# Altium schematic sheet BT2215.SchDoc(BT2215)
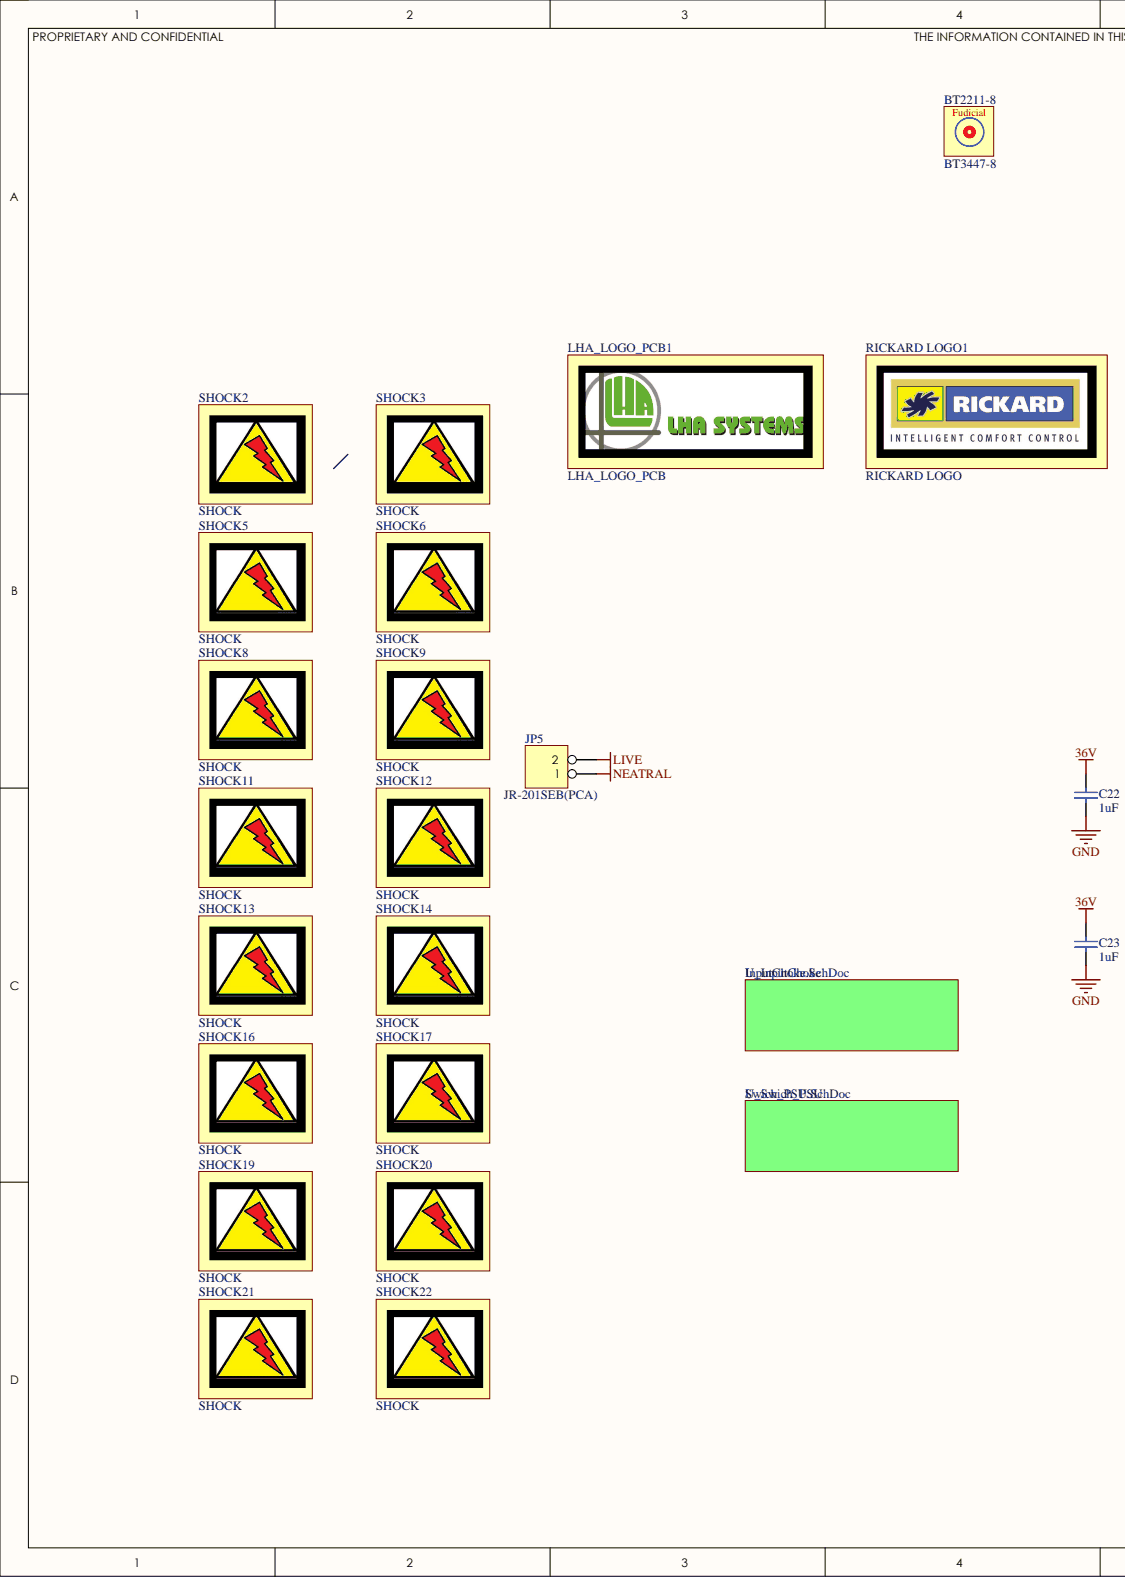
[diagram p0: BT2215.SchDoc, left]
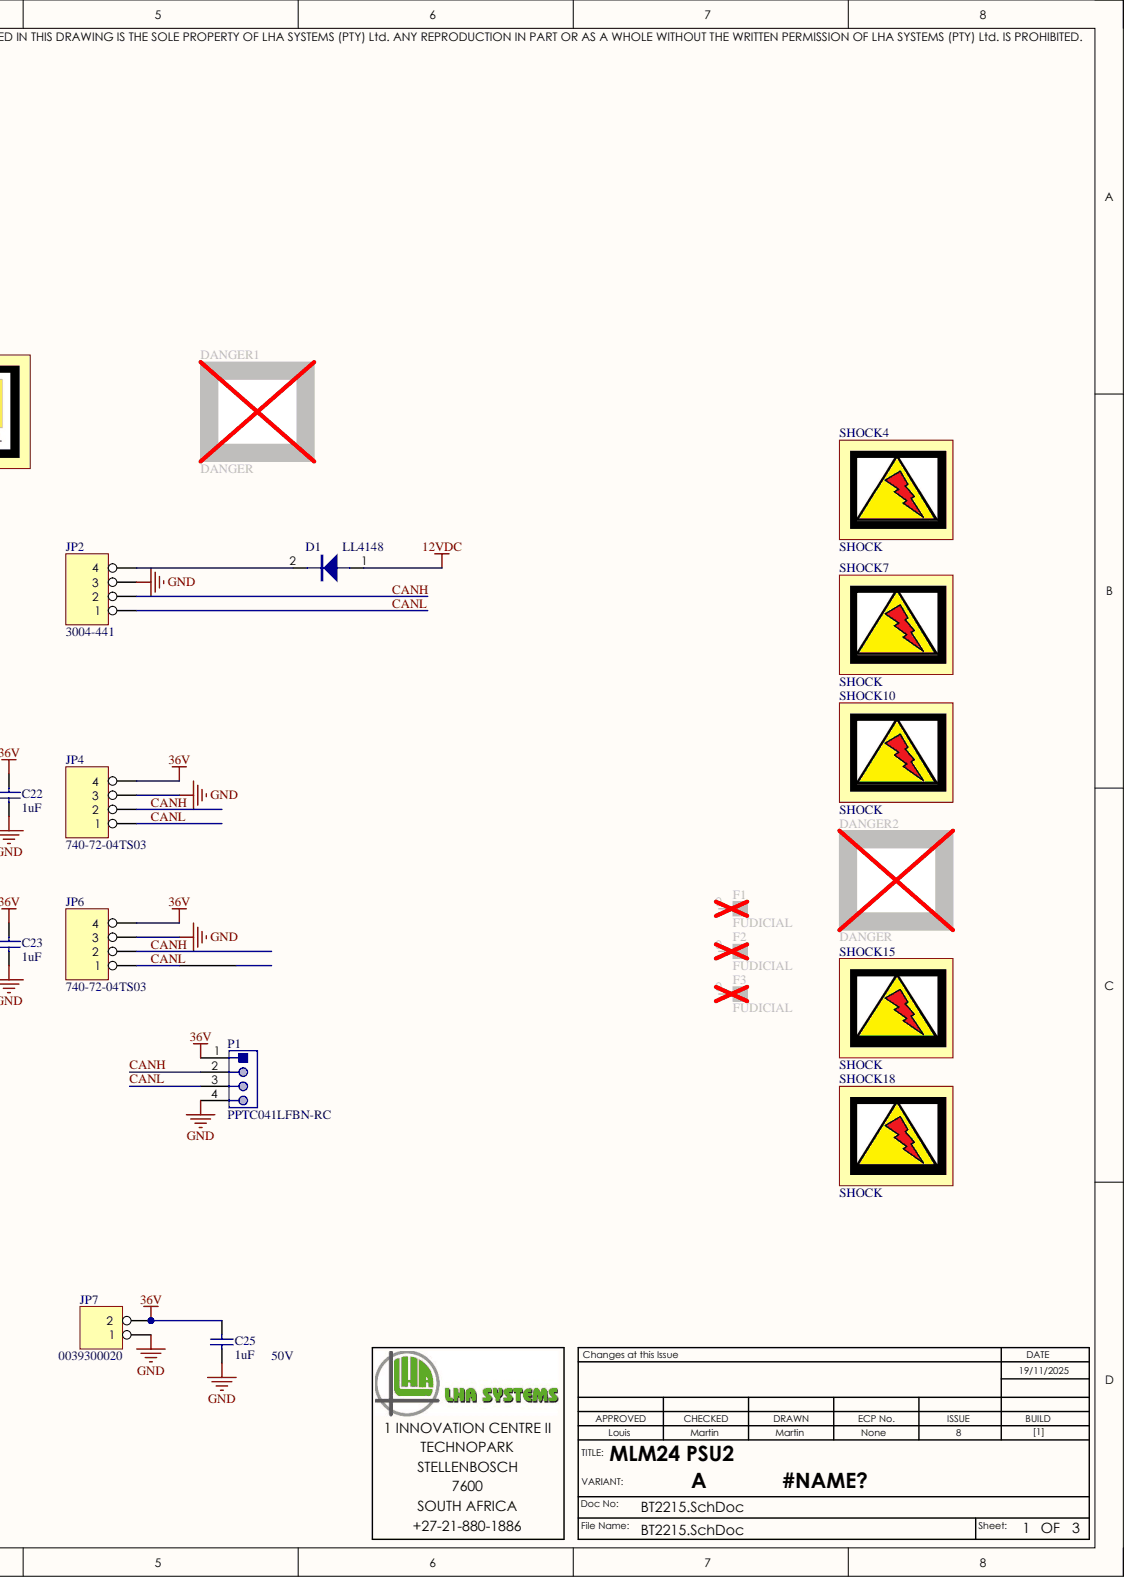
[diagram p1: BT2215.SchDoc, right]

## Parts
BT2211-8  BT3447-8  [PCB_NAME]
C22  1uF  [1206 - OCTO]
C23  1uF  [1206 - OCTO]
C25  1uF  [1206 - OCTO]
D1  LL4148  [MLL34]
DANGER1  DANGER  [DANGER]  (no BOM)
DANGER2  DANGER  [DANGER]  (no BOM)
F1  FUDICIAL  [FUDICIAL]  (no BOM)
F2  FUDICIAL  [FUDICIAL]  (no BOM)
F3  FUDICIAL  [FUDICIAL]  (no BOM)
JP2  3004-441  [RJ9 THL (95003-2441)]
JP4  740-72-04TS03  [MINIFIT-4P]
JP5  JR-201SEB(PCA)  [JR-201SEB(PCA)]
JP6  740-72-04TS03  [MINIFIT-4P]
JP7  0039300020  [MINIFIT JR 2 WAY NO PEG]
LHA_LOGO_PCB1  LHA_LOGO_PCB  [LHA_PCB_LOGO]  (no BOM)
P1  PPTC041LFBN-RC  [Pin Header Female Straight 2.54mm 1x4]
RICKARD_LOGO1  RICKARD LOGO  [RICKARD LOGO]  (no BOM)
SHOCK2  SHOCK  [SHOCK1-SMALL]  (no BOM)
SHOCK3  SHOCK  [SHOCK1-SMALL]  (no BOM)
SHOCK4  SHOCK  [SHOCK1-SMALL]  (no BOM)
SHOCK5  SHOCK  [SHOCK1-SMALL]  (no BOM)
SHOCK6  SHOCK  [SHOCK1-SMALL]  (no BOM)
SHOCK7  SHOCK  [SHOCK1-SMALL]  (no BOM)
SHOCK8  SHOCK  [SHOCK1-SMALL]  (no BOM)
SHOCK9  SHOCK  [SHOCK1-SMALL]  (no BOM)
SHOCK10  SHOCK  [SHOCK1-SMALL]  (no BOM)
SHOCK11  SHOCK  [SHOCK1-SMALL]  (no BOM)
SHOCK12  SHOCK  [SHOCK1-SMALL]  (no BOM)
SHOCK13  SHOCK  [SHOCK1-SMALL]  (no BOM)
SHOCK14  SHOCK  [SHOCK1-SMALL]  (no BOM)
SHOCK15  SHOCK  [SHOCK1-SMALL]  (no BOM)
SHOCK16  SHOCK  [SHOCK1-SMALL]  (no BOM)
SHOCK17  SHOCK  [SHOCK1-SMALL]  (no BOM)
SHOCK18  SHOCK  [SHOCK1-SMALL]  (no BOM)
SHOCK19  SHOCK  [SHOCK1-SMALL]  (no BOM)
SHOCK20  SHOCK  [SHOCK1-SMALL]  (no BOM)
SHOCK21  SHOCK  [SHOCK1-SMALL]  (no BOM)
SHOCK22  SHOCK  [SHOCK1-SMALL]  (no BOM)

## Nets
12VDC: D1.1
36V: C22.2 C23.2 C25.2 JP4.4 JP6.4 JP7.2 P1.1
GND: C22.1 C23.1 C25.1 JP2.3 JP4.3 JP6.3 JP7.1 P1.4
LIVE: JP5.2
NEATRAL: JP5.1
CANH: JP2.2 JP4.2 JP6.2 P1.2
CANL: JP2.1 JP4.1 JP6.1 P1.3
NetD1_2: D1.2 JP2.4

Not connected: F1 0; F2 0; F3 0

## Library data of the parts
BT2211-8 = PCB_NAME_SYSTEM_PARAMETERS: See LHADescription; LHADescription: Printed Circuit Board; Category: PCB; Component Kind: Standard; Library Name: LHA_LIB_Generic.SchLib; Part Description: Printed Circuit Board
C22 C23 C25 = CAP: Cap Ceramic 10%; Manufacturer: KEMET; Manufacturer Part Number: C1206C105K5RACTU; Part Description: CAP X7R Ceramic 1uF 50V 1206 10%; Dielectric: X7R; LHADescription: Multilayer Ceramic Capacitors MLCC - SMD/SMT 50volts 1uF X7R 10%; Voltage Rated: 50V; Capacitance: 1uF; Case Code (Imperial): 1206; Case Code (Metric): 3216; Category: Capacitors; Component Kind: Standard; ComponentLink1Description: http://www.murata.com/~/media/webrenewal/support/library/catalog/products/capacitor/mlcc/c02e.ashx; ComponentLink1URL: http://www.murata.com/~/media/webrenewal/support/library/catalog/products/capacitor/mlcc/c02e.ashx; ComponentLink2Description: https://datasheet.ciiva.com/27056/2014035-27056208.pdf?src-supplier=Jameco; ComponentLink2URL: https://datasheet.ciiva.com/27056/2014035-27056208.pdf?src-supplier=Jameco; ComponentLink3Description: https://datasheet.ciiva.com/7812/cx7r-7812103.pdf?src-supplier=Element14; ComponentLink3URL: https://datasheet.ciiva.com/7812/cx7r-7812103.pdf?src-supplier=Element14; ComponentLink4Description: https://datasheet.ciiva.com/7812/cx7r-7812104.pdf?src-supplier=Element14; ComponentLink4URL: https://datasheet.ciiva.com/7812/cx7r-7812104.pdf?src-supplier=Element14; ComponentLink5Description: https://datasheet.ciiva.com/46441/1818074-46441208.pdf?src-supplier=Element14; ComponentLink5URL: https://datasheet.ciiva.com/46441/1818074-46441208.pdf?src-supplier=Element14; ComponentLink6Description: https://datasheet.ciiva.com/151/cx7r-151913.pdf?src-supplier=Digi-Key; ComponentLink6URL: https://datasheet.ciiva.com/151/cx7r-151913.pdf?src-supplier=Digi-Key; ComponentLink7Description: https://datasheet.ciiva.com/7812/cx7r-7812105.pdf?src-supplier=Element14; ComponentLink7URL: https://datasheet.ciiva.com/7812/cx7r-7812105.pdf?src-supplier=Element14; ComponentLink8Description: https://datasheet.ciiva.com/16291/cx7r-16291545.pdf?src-supplier=Future+Electronics; ComponentLink8URL: https://datasheet.ciiva.com/16291/cx7r-16291545.pdf?src-supplier=Future+Electronics; ComponentLink9Description: https://datasheet.ciiva.com/151/cx7r-151914.pdf?src-supplier=Digi-Key; ComponentLink9URL: https://datasheet.ciiva.com/151/cx7r-151914.pdf?src-supplier=Digi-Key; ComponentLink10Description: https://datasheet.ciiva.com/151/cx7r-151915.pdf?src-supplier=Digi-Key; ComponentLink10URL: https://datasheet.ciiva.com/151/cx7r-151915.pdf?src-supplier=Digi-Key; ComponentLink11Description: https://datasheet.ciiva.com/25730/cl21a106koqnnng-25730226.pdf?src-supplier=Digi-Key; ComponentLink11URL: https://datasheet.ciiva.com/25730/cl21a106koqnnng-25730226.pdf?src-supplier=Digi-Key; ComponentLink12Description: https://datasheet.ciiva.com/29199/454932-da-01-en-vs-kondensator-0805-29199423.pdf?src-supplier=Conrad; ComponentLink12URL: https://datasheet.ciiva.com/29199/454932-da-01-en-vs-kondensator-0805-29199423.pdf?src-supplier=Conrad; ComponentLink13Description: https://datasheet.ciiva.com/25710/cl31a106kahnnne-25710837.pdf?src-supplier=Digi-Key; ComponentLink13URL: https://datasheet.ciiva.com/25710/cl31a106kahnnne-25710837.pdf?src-supplier=Digi-Key; ComponentLink14Description: https://datasheet.ciiva.com/15195/getdatasheetpartid-355210-15195990.pdf?src-supplier=Verical; ComponentLink14URL: https://datasheet.ciiva.com/15195/getdatasheetpartid-355210-15195990.pdf?src-supplier=Verical; ComponentLink15Description: https://datasheet.ciiva.com/29201/452519-da-01-en-vs-kondensator-1206-29201124.pdf?src-supplier=Conrad; ComponentLink15URL: https://datasheet.ciiva.com/29201/452519-da-01-en-vs-kondensator-1206-29201124.pdf?src-supplier=Conrad; ComponentLink16Description: https://datasheet.ciiva.com/7730/kem-c1002-x7r-smd-7730086.pdf?src-supplier=Element14; ComponentLink16URL: https://datasheet.ciiva.com/7730/kem-c1002-x7r-smd-7730086.pdf?src-supplier=Element14; ComponentLink17Description: https://datasheet.ciiva.com/6074/kem-c1002-x7r-smd-6074697.pdf?src-supplier=Farnell; ComponentLink17URL: https://datasheet.ciiva.com/6074/kem-c1002-x7r-smd-6074697.pdf?src-supplier=Farnell; ComponentLink18Description: https://datasheet.ciiva.com/22749/1918693-22749725.pdf?src-supplier=Element14; ComponentLink18URL: https://datasheet.ciiva.com/22749/1918693-22749725.pdf?src-supplier=Element14; Depth: 1.6mm; Dielectric Material: Ceramic; Height: 1mm; Length: 3.2mm; Library Name: LHA_LIB.SchLib; Max Operating Temperature: 125°C; Min Operating Temperature: -55°C; Mount: Surface Mount; Number of Pins: 2; Package Quantity: 1; PackageCase: 1206; Packaging: Tape and Reel; Pricing 1: 2500=0.06336, 5000=0.05861, 12500=0.05259, 25000=0.05069, 62500=0.04752, 125000=0.04198, 250000=0.03960 (USD); Radiation Hardening: No; REACH SVHC: No SVHC; RoHS: Yes; Stock 1: 97500; Supplier 1: Digi-Key; Supplier Part Number 1: 399-8147-2-ND; Termination: SMD/SMT; Thickness: 0.043inch; Tolerance: 10%; Width: 0.063inch
D1 = DIODE: Manufacturer: Vishay Semiconductor Diodes Division; Capacitance @ Vr, F: 4pF @ 0V, 1MHz; Current - Average Rectified (Io): 300mA (DC); Current - Reverse Leakage @ Vr: 5µA @ 75V; Diode Type: Standard; LHADescription: DIODE GEN PURP 75V 300MA SOD80; Reverse Recovery Time (trr): 4ns; Speed: Fast Recovery =< 500ns, > 200mA (Io); Voltage - DC Reverse (Vr) (Max): 75V; Voltage - Forward (Vf) (Max) @ If: 1V @ 50mA; Category: Discrete Semiconductor Products; Component Kind: Standard; ComponentLink1Description: http://www.vishay.com/docs/85557/ll4148.pdf; ComponentLink1URL: http://www.vishay.com/docs/85557/ll4148.pdf; Description: DIODE; Library Name: LHA_LIB.SchLib; Manufacturer Part Number: LL4148; Mounting Type: Surface Mount; Operating Temperature - Junction: -65°C ~ 175°C; PackageCase: SOD-80; Packaging: Cut Tape (CT); Part Status: Active; Pricing 1: 1=0.15, 10=0.135, 25=0.1284, 100=0.0735, 250=0.05448, 500=0.04522, 1000=0.03084, 2500=0.02621, 5000=0.02364 (USD); RoHS: RoHS Compliant; Stock 1: 249840; Supplier 1: Digi-Key; Supplier Device Package: SOD-80 MiniMELF; Supplier Part Number 1: LL4148-GS08CT-ND; Type: DIODE
DANGER1 DANGER2 = DANGER: DANGER SIGN; Type: SIGN; Library Name: LHA_LIB.SchLib
JP2 = 4 HEADER: Connector RJ9; Manufacturer: PINREX; Connector Type: Jack; LED Color: Does Not Contain LED; LHADescription: CONN MOD JACK 4P4C R/A UNSHLD; Number of Ports: 1; Number of Positions/Contacts: 4p4c (RJ9, RJ10, RJ22); Shielding: Unshielded; Tab Direction: Down; Category: Connectors, Interconnects; Component Kind: Standard; ComponentLink1Description: http://belfuse.com/pdfs/CT640016.pdf; ComponentLink1URL: http://belfuse.com/pdfs/CT640016.pdf; ComponentLink2Description: https://belfuse.com/resources/StewartConnector/Stewart%20Data%20Sheets/CT640016.pdf; ComponentLink2URL: https://belfuse.com/resources/StewartConnector/Stewart%20Data%20Sheets/CT640016.pdf; Contact Finish: Gold; Contact Finish Thickness: 30µin (0.76µm); Contact Material: Copper Alloy; Features: Board Lock; Housing Material: Thermoplastic; Ingress Protection: -; Manufacturer Part Number: 3004-441; Mounting Type: Through Hole; Number of Rows: 1; Operating Temperature: -; Orientation: 90° Angle (Right); PackageCase: 3004-441; Packaging: Tray; Part Description: CONN MOD JACK 4P4C R/A UNSHLD; Part Status: Active; Pricing: 1=0.84, 25=0.7876, 100=0.7219, 250=0.65624, 500=0.525, 1000=0.4725 (USD); Pricing 1: 1=0.8, 10=0.704, 25=0.6624, 50=0.6348, 100=0.6072, 250=0.552, 500=0.4968, 1000=0.4416, 2500=0.4002 (USD); Ratings: -; RoHS: RoHS Compliant; Stock: 8757; Stock 1: 17981; Supplier 1: Digi-Key; Supplier Part Number 1: 380-1017-ND; System: Connector; Termination: Solder; Type: CON
JP4 JP6 = 4 HEADER: 2x2 Minifit Jr RA; Manufacturer: Molex Inc; Connector Type: Header, Shrouded; Contact Length - Post: 0.130" (3.30mm); LHADescription: R/A W/O FLANGE WTOB HDR 4POS; Material Flammability Rating: UL94 V-0; Number of Positions: 4; Number of Positions Loaded: All; Pitch: 0.165" (4.20mm); Shrouding: Shrouded - 4 Wall; Style: Board to Cable/Wire; System: Connector; Applications: General Purpose, Industrial, Telecommunications; Category: Connectors, Interconnects; Color: Beige; Component Kind: Standard; ComponentLink1Description: http://www.molex.com/pdm_docs/sd/874270402_sd.pdf; ComponentLink1URL: http://www.molex.com/pdm_docs/sd/874270402_sd.pdf; Contact Finish: Tin; Contact Finish - Mating: Tin; Contact Finish - Post: Tin; Contact Finish Thickness: 100µin (2.54µm); Contact Finish Thickness - Mating: 100µin (2.54µm); Contact Finish Thickness - Post: 100µin (2.54µm); Contact Length - Mating: -; Contact Material: Brass; Contact Mating Length: -; Contact Shape: Square; Contact Type: Male Pin; Current Rating: -; Fastening Type: Locking Ramp; Features: -; Ingress Protection: -; Insulation Color: Beige; Insulation Height: 0.394" (10.00mm); Insulation Material: Polyamide (PA46), Nylon 4/6; Manufacturer Part Number: 740-72-04TS03; Mated Stacking Heights: -; Mounting Type: Through Hole, Right Angle; Number of Rows: 2; Operating Temperature: -; Overall Contact Length: -; PackageCase: 087427-0402  MINIFIT; Packaging: Tray; Part Description: R/A W/O FLANGE WTOB HDR 4POS; Part Status: Active; Pitch - Mating: 0.165" (4.20mm); Pricing: 1=1.32, 10=1.158, 50=1.0442, 100=0.9988, 250=0.908, 500=0.8172, 1000=0.7264, 2500=0.6583, 5000=0.6356 (USD); Pricing 1: 1=1.09, 10=0.957, 50=0.863, 100=0.8254, 250=0.7504, 500=0.67536, 1000=0.60032, 2500=0.54404, 5000=0.52528 (USD); RoHS: RoHS Compliant; Row Spacing: 0.165" (4.20mm); Row Spacing - Mating: 0.165" (4.20mm); Stock: 813; Stock 1: 5200; Supplier 1: Digi-Key; Supplier Part Number 1: WM4464-ND; Termination: Solder; Type: CON; Voltage - Rated: -
JP5 = HEADER 2: Figure 8 Power Connector; Approvals: CSA, UL, VDE; Connector Style: IEC 320-C8, Non-Polarized; Connector Type: Receptacle, Male Pins; Current - IEC: 2.5A; Filter Type: Unfiltered - Commercial; Fuse: No; LHADescription: PWR ENT RCPT IEC320-C8 PNL SLDR; Number of Positions: 2; Panel Thickness: 0.063" (1.60mm); Voltage - IEC: 250VAC; Category: Connectors, Interconnects; Component Kind: Standard; Current - UL: -; Features: Board Lock; Fuse Holder, Drawer: -; Ingress Protection: -; Library Name: LHA_LIB.SchLib; Manufacturer Part Number: JR-201SEB(PCA); Material Flammability Rating: -; Mounting Type: Panel Mount, Snap-In; Through Hole, Right Angle; Packaging: Bulk; Panel Cutout Dimensions: -; Part Description: PWR ENT RCPT IEC320-C8 PNL SLDR; RoHS: RoHS Compliant; Switch Features: -; System: Connector; Termination: Solder; Type: CON; Voltage - UL: -
JP7 = HEADER 2: Header 4.20mm, dual row, RA,pcb lock, 2w; Manufacturer: Molex Inc; Connector Type: Header, Shrouded; Contact Length - Post: 0.142" (3.60mm); LHADescription: CONN HEADER 2POS 4.2MM R/A TIN; Material Flammability Rating: UL94 V-0; Number of Positions: 2; Number of Positions Loaded: All; Row Spacing: 0.165" (4.20mm); Shrouding: Shrouded - 4 Wall; Style: Board to Cable/Wire; System: Connector; Applications: General Purpose, Industrial, Telecommunications; Category: Connectors, Interconnects; Color: Natural; Component Kind: Standard; ComponentLink1Description: http://www.molex.com/pdm_docs/sd/039300020_sd.pdf; ComponentLink1URL: http://www.molex.com/pdm_docs/sd/039300020_sd.pdf; Contact Finish: Tin; Contact Finish - Mating: Tin; Contact Finish - Post: Tin; Contact Finish Thickness: 100µin (2.54µm); Contact Finish Thickness - Mating: 100µin (2.54µm); Contact Finish Thickness - Post: 100µin (2.54µm); Contact Length - Mating: -; Contact Material: Brass; Contact Mating Length: -; Contact Shape: Square; Contact Type: Male Pin; Current Rating: -; Fastening Type: Locking Ramp; Features: Board Lock; Ingress Protection: -; Insulation Color: Natural; Insulation Height: 0.378" (9.60mm); Insulation Material: Polyamide (PA66), Nylon 6/6; Library Name: LHA_LIB.SchLib; Manufacturer Part Number: 0039300020; Mated Stacking Heights: -; Mounting Type: Through Hole, Right Angle; Number of Rows: 2; Operating Temperature: -; Overall Contact Length: -; Packaging: Tray; Part Description: CONN HEADER 2POS 4.2MM R/A TIN; Part Status: Active; Pitch: -; Pitch - Mating: -; Pricing 1: 1=0.7, 10=0.656, 25=0.59, 50=0.5244, 100=0.5026, 250=0.45884, 500=0.437, 1000=0.36053, 2500=0.32775 (USD); RoHS: RoHS Compliant; Row Spacing - Mating: 0.165" (4.20mm); Stock 1: 952; Supplier 1: Digi-Key; Supplier Part Number 1: WM21351-ND; Termination: Solder; Type: CON; Voltage - Rated: -
P1 = Header 4x1: Male Header, Pitch 2.54 mm, 1 x 3 Position, Height 8.38 mm, Tail Length 3.18 mm, RoHS, Bulk; Manufacturer: Sullins; Connector Type: Header,Socket; LHADescription: CONN HDR 4POS 0.1 TIN PCB; Number of Positions: 4; Pitch: 0.1inch; Category: Connectors, Interconnects; Color: Black; Component Kind: Standard; ComponentLink1Description: https://media.digikey.com/pdf/Data%20Sheets/Sullins%20PDFs/Female_Headers.100_DS.pdf?src-supplier=Digi-Key; ComponentLink1URL: https://media.digikey.com/pdf/Data%20Sheets/Sullins%20PDFs/Female_Headers.100_DS.pdf?src-supplier=Digi-Key; ComponentLink2Description: http://www.sullinscorp.com/catalogs/78_Page80-81_HEADER_.100_2.54mm_FEMALE.pdf?src-supplier=Future+Electronics; ComponentLink2URL: http://www.sullinscorp.com/catalogs/78_Page80-81_HEADER_.100_2.54mm_FEMALE.pdf?src-supplier=Future+Electronics; Contact Material: Bronze; Contact Plating: Tin; Height: 8.5mm; Housing Material: Plastic; Lead Free: Lead Free; Length: 10.7mm; Library Name: LHA_LIB_Generic.SchLib; Manufacturer Part Number: PPTC041LFBN-RC; Mount: Through Hole; Number of Rows: 1; Package Quantity: 1000; Packaging: Bulk; Part Description: CONN HDR 4POS 0.1 TIN PCB; Pricing 1: 1=0.45000, 10=0.42400, 100=0.32500, 500=0.28260, 1000=0.23314, 5000=0.20488, 10000=0.19076 (USD); RoHS: Yes; Stock 1: 9743; Supplier 1: Digi-Key; Supplier Part Number 1: S7002-ND; Termination: Solder; Width: 2.5mm
SHOCK2-SHOCK22 = SHOCK: SHOCK SIGN; Type: SIGN; Library Name: LHA_LIB.SchLib
F1-F3 = FUDICIAL: Description: FUDICIAL; Library Name: LHA_LIB.SchLib
LHA_LOGO_PCB1 = LHA_LOGO_PCB: Library Name: LHA_LIB.SchLib; Type: SIGN
RICKARD_LOGO1 = RICKARD LOGO: Description: RICKARD LOGO; Type: SIGN; Library Name: LHA_LIB.SchLib
